# Altium schematic sheet S2_UserInterface.SchDoc(S2_UserInterface)
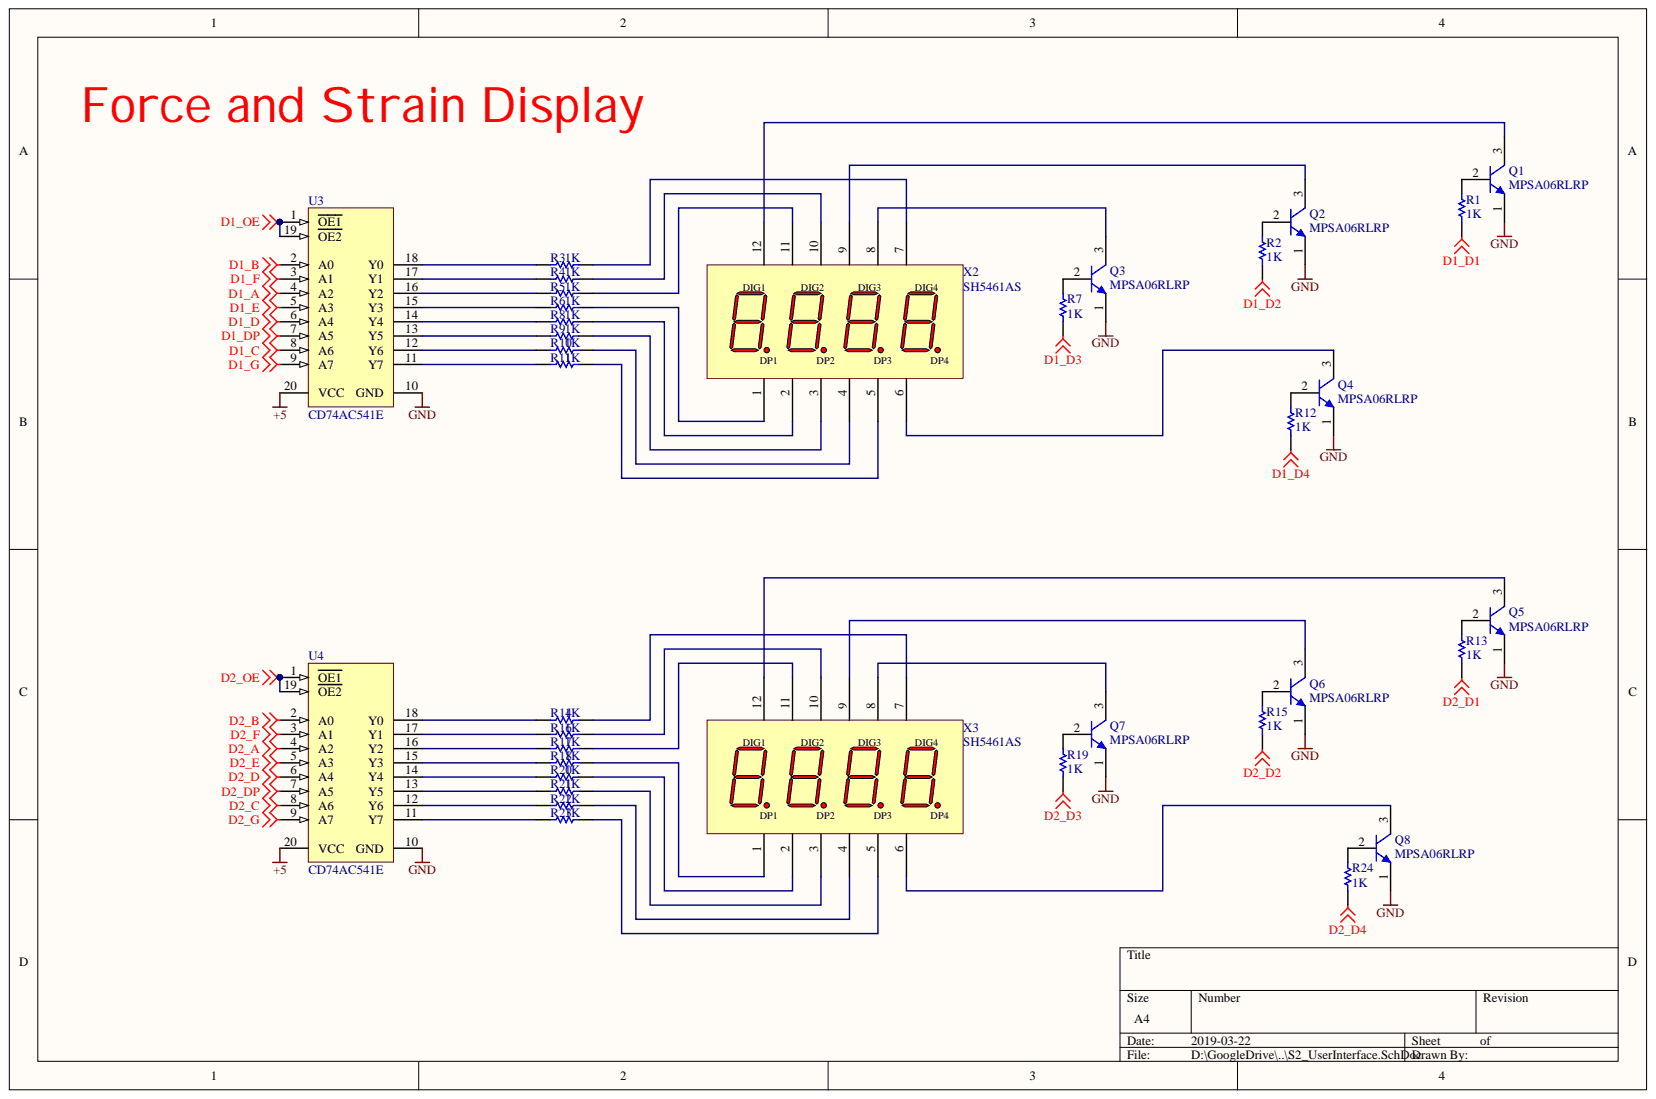
[diagram p0: S2_UserInterface.SchDoc]

## Parts
Q1  MPSA06RLRP  [ONSC-TO-92-3-29-11_AM_BL]
Q2  MPSA06RLRP  [ONSC-TO-92-3-29-11_AM_BL]
Q3  MPSA06RLRP  [ONSC-TO-92-3-29-11_AM_BL]
Q4  MPSA06RLRP  [ONSC-TO-92-3-29-11_AM_BL]
Q5  MPSA06RLRP  [ONSC-TO-92-3-29-11_AM_BL]
Q6  MPSA06RLRP  [ONSC-TO-92-3-29-11_AM_BL]
Q7  MPSA06RLRP  [ONSC-TO-92-3-29-11_AM_BL]
Q8  MPSA06RLRP  [ONSC-TO-92-3-29-11_AM_BL]
R1  value 1K  [AXIAL-0.3-3D]  (hidden comment: Res1)
R2  value 1K  [AXIAL-0.3-3D]  (hidden comment: Res1)
R3  value 1K  [AXIAL-0.3-3D]  (hidden comment: Res1)
R4  value 1K  [AXIAL-0.3-3D]  (hidden comment: Res1)
R5  value 1K  [AXIAL-0.3-3D]  (hidden comment: Res1)
R6  value 1K  [AXIAL-0.3-3D]  (hidden comment: Res1)
R7  value 1K  [AXIAL-0.3-3D]  (hidden comment: Res1)
R8  value 1K  [AXIAL-0.3-3D]  (hidden comment: Res1)
R9  value 1K  [AXIAL-0.3-3D]  (hidden comment: Res1)
R10  value 1K  [AXIAL-0.3-3D]  (hidden comment: Res1)
R11  value 1K  [AXIAL-0.3-3D]  (hidden comment: Res1)
R12  value 1K  [AXIAL-0.3-3D]  (hidden comment: Res1)
R13  value 1K  [AXIAL-0.3-3D]  (hidden comment: Res1)
R14  value 1K  [AXIAL-0.3-3D]  (hidden comment: Res1)
R15  value 1K  [AXIAL-0.3-3D]  (hidden comment: Res1)
R16  value 1K  [AXIAL-0.3-3D]  (hidden comment: Res1)
R17  value 1K  [AXIAL-0.3-3D]  (hidden comment: Res1)
R18  value 1K  [AXIAL-0.3-3D]  (hidden comment: Res1)
R19  value 1K  [AXIAL-0.3-3D]  (hidden comment: Res1)
R20  value 1K  [AXIAL-0.3-3D]  (hidden comment: Res1)
R21  value 1K  [AXIAL-0.3-3D]  (hidden comment: Res1)
R22  value 1K  [AXIAL-0.3-3D]  (hidden comment: Res1)
R23  value 1K  [AXIAL-0.3-3D]  (hidden comment: Res1)
R24  value 1K  [AXIAL-0.3-3D]  (hidden comment: Res1)
U3  CD74AC541E  [N0020A]
U4  CD74AC541E  [N0020A]
X2  SH5461AS  [4x7Seg]
X3  SH5461AS  [4x7Seg]

## Nets
+5: U3.20(VCC) U4.20(VCC)
D1_A: U3.4(A2)
D1_B: U3.2(A0)
D1_C: U3.8(A6)
D1_D: U3.6(A4)
D1_D1: R1.1
D1_D2: R2.1
D1_D3: R7.1
D1_D4: R12.1
D1_DP: U3.7(A5)
D1_E: U3.5(A3)
D1_F: U3.3(A1)
D1_G: U3.9(A7)
D1_OE: U3.1(OE1) U3.19(OE2)
D2_A: U4.4(A2)
D2_B: U4.2(A0)
D2_C: U4.8(A6)
D2_D: U4.6(A4)
D2_D1: R13.1
D2_D2: R15.1
D2_D3: R19.1
D2_D4: R24.1
D2_DP: U4.7(A5)
D2_E: U4.5(A3)
D2_F: U4.3(A1)
D2_G: U4.9(A7)
D2_OE: U4.1(OE1) U4.19(OE2)
GND: Q1.1 Q2.1 Q3.1 Q4.1 Q5.1 Q6.1 Q7.1 Q8.1 U3.10(GND) U4.10(GND)
NetQ1_2: Q1.2 R1.2
NetQ1_3: Q1.3 X2.12
NetQ2_2: Q2.2 R2.2
NetQ2_3: Q2.3 X2.9
NetQ3_2: Q3.2 R7.2
NetQ3_3: Q3.3 X2.8
NetQ4_2: Q4.2 R12.2
NetQ4_3: Q4.3 X2.6
NetQ5_2: Q5.2 R13.2
NetQ5_3: Q5.3 X3.12
NetQ6_2: Q6.2 R15.2
NetQ6_3: Q6.3 X3.9
NetQ7_2: Q7.2 R19.2
NetQ7_3: Q7.3 X3.8
NetQ8_2: Q8.2 R24.2
NetQ8_3: Q8.3 X3.6
NetR3_1: R3.1 X2.7
NetR3_2: R3.2 U3.18(Y0)
NetR4_1: R4.1 X2.10
NetR4_2: R4.2 U3.17(Y1)
NetR5_1: R5.1 X2.11
NetR5_2: R5.2 U3.16(Y2)
NetR6_1: R6.1 X2.1
NetR6_2: R6.2 U3.15(Y3)
NetR8_1: R8.1 X2.2
NetR8_2: R8.2 U3.14(Y4)
NetR9_1: R9.1 X2.3
NetR9_2: R9.2 U3.13(Y5)
NetR10_1: R10.1 X2.4
NetR10_2: R10.2 U3.12(Y6)
NetR11_1: R11.1 X2.5
NetR11_2: R11.2 U3.11(Y7)
NetR14_1: R14.1 X3.7
NetR14_2: R14.2 U4.18(Y0)
NetR16_1: R16.1 X3.10
NetR16_2: R16.2 U4.17(Y1)
NetR17_1: R17.1 X3.11
NetR17_2: R17.2 U4.16(Y2)
NetR18_1: R18.1 X3.1
NetR18_2: R18.2 U4.15(Y3)
NetR20_1: R20.1 X3.2
NetR20_2: R20.2 U4.14(Y4)
NetR21_1: R21.1 X3.3
NetR21_2: R21.2 U4.13(Y5)
NetR22_1: R22.1 X3.4
NetR22_2: R22.2 U4.12(Y6)
NetR23_1: R23.1 X3.5
NetR23_2: R23.2 U4.11(Y7)

## Library data of the parts
Q1-Q8 = CMP-1048-01505-1: 80 V, 0.5 A, Amplifier Transistor, NPN Transistor, 3-Pin TO-92, Ammo Box; Manufacturer: On Semiconductor; Note1: 0; IC Continuous (A): 0.5; fT Min (MHz): 100; RoHS: FALSE; Polarity: NPN; PartNumber: MPSA06RLRP; Packing: Ammo Box; PackageVersion: Rev. AM; PackageReference: TO-92-3-29-11_AM_BL; PackageDescription: 3-Pin Transistor Outline, Body 4.825 x 3.685 mm, Pitch 1.27 mm; Mounting Technology: Through Hole; Library Name: Altium Content Vault; DatasheetVersion: Rev. 3; ComponentLink3URL: http://www.onsemi.com/pub_link/Collateral/MAC97-D.PDF; ComponentLink3Description: Package Specification; ComponentLink2URL: http://www.onsemi.cn/pub_link/Collateral/MPSA05-D.PDF; ComponentLink2Description: Datasheet; ComponentLink1URL: http://www.onsemi.com/; ComponentLink1Description: Manufacturer URL; Component Kind: Standard
R1-R24 = Res1: Resistor; Simulation: RESISTOR; Signal Integrity: Res; Published: 8-Jun-2000; PackageReference: AXIAL-0.3; PackageDescription: Axial Device, Thru-Hole; 2 Leads; 0.3 in Pin Spacing; Library Name: Miscellaneous Devices.IntLib; LatestRevisionNote: Re-released for DXP Platform.; LatestRevisionDate: 17-Jul-2002; Component Kind: Standard; Publisher: Altium Limited
U3 U4 = CMP-0440-00981-2: Non-Inverting Octal Buffer/Line Drivers with 3-State Outputs, N0020A, TUBE; Manufacturer: Texas Instruments; Voltage(Nom)(V): 1.5,3.3,5; VCC(Min)(V): 1.5; VCC(Max)(V): 5.5; tpd @ Nom Voltage(Max)(ns): 77,8.6,6.2; Rating: Catalog; Output Type: CMOS; ICC @ Nom Voltage(Max)(mA): 0.16; F @ Nom Voltage(Max)(Mhz): 60; Bits(#): 8; PartNumber: CD74AC541E; PackageVersion: http://www.ti.com/lit/ml/mpdi002c/mpdi002c.pdf; PackageReference: N0020A; Operating Temperature Range(C): -55 to 125; Library Name: Altium Content Vault; Input Type: CMOS; Generic Part Number: CD74AC541; DatasheetVersion: SCHS285A; ComponentLink2URL: http://www.ti.com/lit/ds/symlink/cd74ac541.pdf; ComponentLink2Description: Datasheet; ComponentLink1URL: http://www.ti.com/; ComponentLink1Description: Manufacturer URL; Component Kind: Standard; Code_JEITA: 5.08; Code_IPC: MS-001
X2 X3 = 4x7Seg: 4 Character 7 Segment Display, Common Cathode; Library Name: Custom.SchLib; Component Kind: Standard
